# Altium schematic sheet PSU.SchDoc
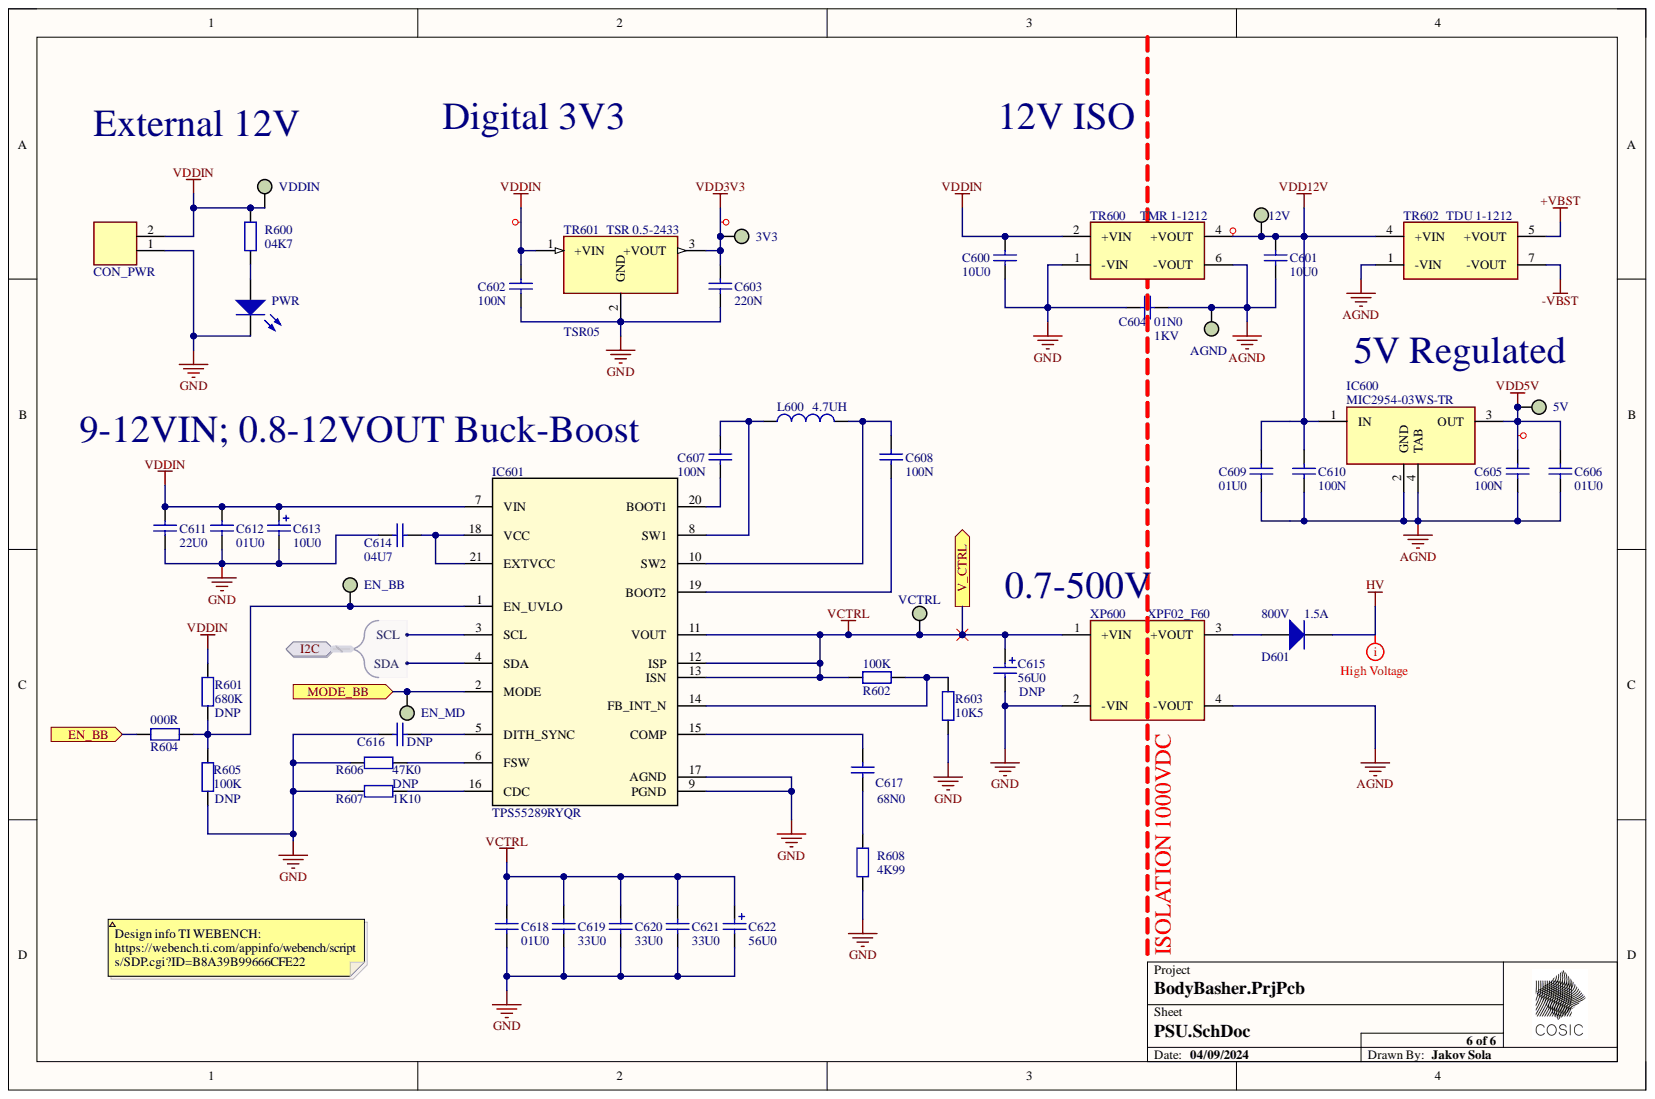
[diagram p0: PSU.SchDoc]

## Parts
3V3  TP  [TP]  (value not drawn)
5V  TP  [TP]  (value not drawn)
12V  TP  [TP]  (value not drawn)
AGND  TP  [TP]  (value not drawn)
C600  VALUE_4C: 10U0  [CAPC0603N]  (hidden comment: Cap)
C601  VALUE_4C: 10U0  [CAPC0603N]  (hidden comment: Cap)
C602  value 100N  [CAPC0603N]  (hidden comment: Cap)
C603  value 220N  [CAPC0603N]  (hidden comment: Cap)
C604  VALUE_4C: 01N0  VOLTAGE: 1KV  [CAPC1206N]  (hidden comment: Cap)
C605  value 100N  [CAPC0603N]  (hidden comment: Cap)
C606  VALUE_4C: 01U0  [CAPC0603N]  (hidden comment: Cap)
C607  value 100N  [CAPC0603N]  (hidden comment: Cap)
C608  value 100N  [CAPC0603N]  (hidden comment: Cap)
C609  VALUE_4C: 01U0  [CAPC0603N]  (hidden comment: Cap)
C610  value 100N  [CAPC0603N]  (hidden comment: Cap)
C611  value 22U0  [CAPC1206N]  (hidden comment: Cap)
C612  VALUE_4C: 01U0  [CAPC0603N]  (hidden comment: Cap)
C613  VALUE_4C: 10U0  [C]  (hidden comment: CapPol)
C614  value 04U7  [CAPC0603N]  (hidden comment: Cap)
C615  POPULATE: DNP  VALUE_4C: 56U0  [20SVPF56MX]  (hidden comment: CapPol)
C616  POPULATE: DNP  [CAPC0603N]  (hidden comment: Cap)
C617  VALUE_4C: 68N0  [CAPC0603N]  (hidden comment: Cap)
C618  VALUE_4C: 01U0  [CAPC0603N]  (hidden comment: Cap)
C619  VALUE_4C: 33U0  [CAPC1206N]  (hidden comment: Cap)
C620  VALUE_4C: 33U0  [CAPC1206N]  (hidden comment: Cap)
C621  VALUE_4C: 33U0  [CAPC1206N]  (hidden comment: Cap)
C622  VALUE_4C: 56U0  [20SVPF56MX]  (hidden comment: CapPol)
CON_PWR  CON_2P  [1711725]  (value not drawn)
D601  IF: 1.5A  VR: 800V  [DIOSMB]  (hidden comment: Diode)
EN_BB  TP  [TP]  (value not drawn)
EN_MD  TP  [TP]  (value not drawn)
IC600  MIC2954-03WS-TR  [SOT230P700X180-4N]
IC601  TPS55289RYQR  [RYQ0021A-MFG]
L600  INDUCTANCE: 4.7UH  [SRN80404R7Y]  (hidden comment: Inductor)
PWR  LED  [LED0603_GREEN]  (value not drawn)
R600  value 04K7  [RESC0603N]  (hidden comment: Res)
R601  value 680K  POPULATE: DNP  [RESC0603N]  (hidden comment: Res)
R602  value 100K  [RESC0603N]  (hidden comment: Res)
R603  value 10K5  [RESC0603N]  (hidden comment: Res)
R604  value 000R  [RESC0603N]  (hidden comment: Res)
R605  value 100K  POPULATE: DNP  [RESC0603N]  (hidden comment: Res)
R606  VALUE_4C: 47K0  [RESC0603N]  (hidden comment: Res)
R607  POPULATE: DNP  VALUE_4C: 1K10  [RESC0603N]  (hidden comment: Res)
R608  value 4K99  [RESC0603N]  (hidden comment: Res)
TR600  TMR1  [TMR1]
TR601  TSR05  [TSR05]
TR602  TDU1  [TDU1]
VCTRL  TP  [TP]  (value not drawn)
VDDIN  TP  [TP]  (value not drawn)
XP600  XPF02_F60  [XPF02_F60]

## Ports
EN_BB, I2C, I2C.SCL, I2C.SDA, MODE_BB, V_CTRL

## Nets
+VBST: TR602.5(+VOUT)
-VBST: TR602.7(-VOUT)
AGND: AGND.TP C601.1 C604.2 C605.1 C606.1 C609.1 C610.1 IC600.2(GND) IC600.4(TAB) TR600.6(-VOUT) TR602.1(-VIN) XP600.4(-VOUT)
GND: C600.1 C602.1 C603.1 C604.1 C611.1 C612.1 C613.2 C614.1 C615.2 C616.2 C618.1 C619.1 C620.1 C621.1 C622.2 CON_PWR.1 IC601.9(PGND) IC601.17(AGND) PWR.2 R603.2 R605.1 R606.2 R607.1 R608.1 TR600.1(-VIN) TR601.2 XP600.2(-VIN)
HV: D601.2
VDD3V3: 3V3.TP C603.2 TR601.3
VDD5V: 5V.TP C605.2 C606.2 IC600.3(OUT)
VDD12V: 12V.TP C601.2 C609.2 C610.2 IC600.1(IN) TR600.4(+VOUT) TR602.4(+VIN)
VDDIN: C600.2 C602.2 C611.2 C612.2 C613.1 CON_PWR.2 IC601.7(VIN) R600.2 R601.2 TR600.2(+VIN) TR601.1 VDDIN.TP
EN_BB: R604.1 ; port EN_BB
MODE_BB: EN_MD.TP IC601.2(MODE) ; port MODE_BB
VCTRL: C615.1 C618.2 C619.2 C620.2 C621.2 C622.1 IC601.11(VOUT) IC601.12(ISP) IC601.13(ISN) R602.1 VCTRL.TP XP600.1(+VIN) ; port V_CTRL
NetC607_1: C607.1 IC601.20(BOOT1)
NetC607_2: C607.2 IC601.8(SW1) L600.1
NetC608_1: C608.1 IC601.19(BOOT2)
NetC608_2: C608.2 IC601.10(SW2) L600.2
NetC614_2: C614.2 IC601.18(VCC) IC601.21(EXTVCC)
NetC616_1: C616.1 IC601.5(DITH_SYNC)
NetC617_1: C617.1 R608.2
NetC617_2: C617.2 IC601.15(COMP)
NetD601_1: D601.1 XP600.3(+VOUT)
NetEN_BB_TP: EN_BB.TP IC601.1(EN_UVLO) R601.1 R604.2 R605.2
NetIC601_3: IC601.3(SCL) ; port I2C
NetIC601_4: IC601.4(SDA) ; port I2C
NetIC601_6: IC601.6(FSW) R606.1
NetIC601_14: IC601.14(FB_INT_N) R602.2 R603.1
NetIC601_16: IC601.16(CDC) R607.2
NetPWR_1: PWR.1 R600.1

## Library data of the parts
3V3 5V 12V AGND EN_BB EN_MD VCTRL VDDIN = TP: Database Table Name: Misc$; DESCR: TESTPOINT 1.5MM; POPULATE: NA; Component Kind: Standard; Library Name: Other_passive.Dblib; MANUFCODE: TP
C600 C601 = ZRB18AR61E106ME01L: MANUF: MURATA; Database Table Name: Fixed_capacitors$; DESCR: CAP 10U0 0603 25V X5R 20%; POPULATE: YES; TOLERANCE: 0.2; VALUE: 10U; VALUE_PF: 10000000; VENDOR: FARNELL INONE; VENDORCODE: 2469398; VOLTAGE: 25V; Component Kind: Standard; Library Name: Capacitors.DbLib; MANUFCODE: ZRB18AR61E106ME01L; PACKAGE: 0603; TECHNOLOGY: X5R
C602 C605 C607 C608 C610 = CL10B104KA8WPNC: MANUF: SAMSUNG; Database Table Name: Fixed_capacitors$; DESCR: CAP 100N 0603 25V X7R 10%; POPULATE: YES; TOLERANCE: 0.1; VENDOR: DIGI-KEY; VENDORCODE: 1276-6717-1-ND; VOLTAGE: 25V; Component Kind: Standard; Library Name: Capacitors.DbLib; MANUFCODE: CL10B104KA8WPNC; PACKAGE: 0603; TECHNOLOGY: X7R; VALUE_4C: 100N
C603 = AC0603KRX7R6BB224: MANUF: YAGEO; Database Table Name: Fixed_capacitors$; DESCR: CAP 220N 0603 10V X7R 10%; POPULATE: YES; TOLERANCE: 0.1; VENDOR: DIGI-KEY; VENDORCODE: 311-3103-1-ND; VOLTAGE: 10V; Component Kind: Standard; Library Name: Capacitors.DbLib; MANUFCODE: AC0603KRX7R6BB224; PACKAGE: 0603; TECHNOLOGY: X7R; VALUE_4C: 220N
C604 = 1206AC102KAT2A: MANUF: AVX; Database Table Name: Fixed_capacitors$; DESCR: CAP 01N0 1206 1KV X7R 10%; POPULATE: YES; TOLERANCE: 0.1; VALUE_PF: 1000; VENDOR: FARNELL INONE; VENDORCODE: 1216463; Component Kind: Standard; Library Name: Capacitors.DbLib; MANUFCODE: 1206AC102KAT2A; PACKAGE: 1206; TECHNOLOGY: X7R
C606 C609 = C0603C105K4PAC-TU: MANUF: KEMET; Database Table Name: Fixed_capacitors$; DESCR: CAP 01U0 0603 16V X5R 10%; POPULATE: YES; TOLERANCE: 0.1; VALUE_PF: 1000000; VENDOR: FARNELL INONE; VENDORCODE: 1463388; VOLTAGE: 16V; Component Kind: Standard; Library Name: Capacitors.DbLib; MANUFCODE: C0603C105K4PAC-TU; PACKAGE: 0603; TECHNOLOGY: X5R
C611 = CL31A226KAHNNNE: MANUF: SAMSUNG; Database Table Name: Fixed_capacitors$; DESCR: CAP 22U0 1206 25V X5R 10%; POPULATE: YES; TOLERANCE: 0.1; VALUE_PF: 22000000; VENDOR: MOUSER; VENDORCODE: 187-CL31A226KAHNNNE; VOLTAGE: 25V; Component Kind: Standard; Library Name: Capacitors.DbLib; MANUFCODE: CL31A226KAHNNNE; PACKAGE: 1206; TECHNOLOGY: X5R; VALUE_4C: 22U0
C612 C618 = C0603C105K3PAC: MANUF: KEMET; Database Table Name: Fixed_capacitors$; DESCR: CAP 01U0 0603 25V X5R 10%; POPULATE: YES; TOLERANCE: 0.1; VALUE_PF: 1000000; VENDOR: FARNELL INONE; VENDORCODE: 1288202; VOLTAGE: 25V; Component Kind: Standard; Library Name: Capacitors.DbLib; MANUFCODE: C0603C105K3PAC; PACKAGE: 0603; TECHNOLOGY: X5R
C613 = TAJC106K025RNJ: MANUF: AVX; Database Table Name: Fixed_capacitors$; DESCR: CAP 10U0 C 25V TANT 10%; POPULATE: YES; TOLERANCE: 0.1; VALUE: 10U; VALUE_PF: 10000000; VENDOR: FARNELL INONE; VENDORCODE: 197518; VOLTAGE: 25V; Component Kind: Standard; Library Name: Capacitors.DbLib; MANUFCODE: TAJC106K025RNJ; PACKAGE: C; TECHNOLOGY: TANT
C614 = GRM185R61C475KE11D: MANUF: MURATA; Database Table Name: Fixed_capacitors$; DESCR: CAP 04U7 0603 16V X5R 10%; POPULATE: YES; TOLERANCE: 0.1; VALUE_PF: 4700000; VENDOR: DIGI-KEY; VENDORCODE: 490-14459-1-ND; VOLTAGE: 16V; Component Kind: Standard; Library Name: Capacitors.DbLib; MANUFCODE: GRM185R61C475KE11D; PACKAGE: 603; TECHNOLOGY: X5R; VALUE_4C: 04U7
C615 = 20SVPF56MX: MANUF: PANASONIC; Database Table Name: Fixed_capacitors$; DESCR: CAP 56U0 5X5.9 20V 20%; TOLERANCE: 0.2; VALUE: 56U; VALUE_PF: 56000000; VENDOR: MOUSER; VENDORCODE: 667-20SVPF56MX; VOLTAGE: 20V; Component Kind: Standard; Library Name: Capacitors.DbLib; MANUFCODE: 20SVPF56MX; PACKAGE: 5X5.9
C616 = C0603C105K3PAC: MANUF: KEMET; Database Table Name: Fixed_capacitors$; DESCR: CAP 01U0 0603 25V X5R 10%; TOLERANCE: 0.1; VALUE_4C: 01U0; VALUE_PF: 1000000; VENDOR: FARNELL INONE; VENDORCODE: 1288202; VOLTAGE: 25V; Component Kind: Standard; Library Name: Capacitors.DbLib; MANUFCODE: C0603C105K3PAC; PACKAGE: 0603; TECHNOLOGY: X5R
C617 = C0603C683K8RACTU: MANUF: KEMET; Database Table Name: Fixed_capacitors$; DESCR: CAP 68N0 0603 10V X7R 10%; POPULATE: YES; TOLERANCE: 0.1; VALUE: 68N; VENDOR: DIGI-KEY; VENDORCODE: 399-9122-1-ND; VOLTAGE: 10V; Component Kind: Standard; Library Name: Capacitors.DbLib; MANUFCODE: C0603C683K8RACTU; PACKAGE: 0603; TECHNOLOGY: X7R
C619-C621 = C3216X5R1E336M160AC: MANUF: TDK; Database Table Name: Fixed_capacitors$; DESCR: CAP 33U0 1206 25V X5R 20%; POPULATE: YES; TOLERANCE: 0.2; VALUE: 33U; VALUE_PF: 33000000; VENDOR: MOUSER; VENDORCODE: 810-C3216X5R1E336M; VOLTAGE: 25V; Component Kind: Standard; Library Name: Capacitors.DbLib; MANUFCODE: C3216X5R1E336M160AC; PACKAGE: 1206; TECHNOLOGY: X5R
C622 = 20SVPF56MX: MANUF: PANASONIC; Database Table Name: Fixed_capacitors$; DESCR: CAP 56U0 5X5.9 20V 20%; POPULATE: YES; TOLERANCE: 0.2; VALUE: 56U; VALUE_PF: 56000000; VENDOR: MOUSER; VENDORCODE: 667-20SVPF56MX; VOLTAGE: 20V; Component Kind: Standard; Library Name: Capacitors.DbLib; MANUFCODE: 20SVPF56MX; PACKAGE: 5X5.9
CON_PWR = 1711725: MANUF: PHOENIX CONTACT; Database Table Name: Misc$; DESCR: CON PHOENIX 5.08MM 2P SCREW; POPULATE: YES; VENDOR: MOUSER; VENDORCODE: 651-1711725; Component Kind: Standard; Library Name: Other_passive.Dblib; MANUFCODE: 1711725
D601 = RS2K-E3/52T: Default Diode; MANUF: VISHAY; Database Table Name: Power_diodes$; DESCR: DIODE 800V 1.5A SMB; POPULATE: YES; VENDOR: MOUSER; VENDORCODE: 625-RS2K-E3; Component Kind: Standard; Library Name: Diodes.Dblib; MANUFCODE: RS2K-E3/52T; PACKAGE: SMB; QTY: SINGLE
IC600 = MIC2954-03WS-TR: MANUF: MICROCHIP; Database Table Name: IC$; DESCR: IC LDO 2-30VIN 5VOUT 250mA; POPULATE: YES; VENDOR: MOUSER; VENDORCODE: 998-MIC2954-03WSTR; Component Kind: Standard; Library Name: Integrated_circuits.DbLib; MANUFCODE: MIC2954-03WS-TR
IC601 = TPS55289RYQR: MANUF: TI; Database Table Name: IC$; DESCR: IC BUCK-BOOST 30V 8A I2C; POPULATE: YES; VENDOR: MOUSER; VENDORCODE: 595-TPS55289RYQR; Component Kind: Standard; Library Name: Integrated_circuits.DbLib; MANUFCODE: TPS55289RYQR
L600 = SRN8040-4R7Y: MANUF: BOURNS; Database Table Name: Inductors$; DESCR: INDUCTOR 04U7 4A1 R024 SMD; IMAX: 4A1; POPULATE: YES; RESISTANCE: R024; VALUE: 04U7; VENDOR: MOUSER; VENDORCODE: 652-SRN8040-4R7Y; Component Kind: Standard; Library Name: Other_passive.Dblib; MANUFCODE: SRN8040-4R7Y; TYPE: INDUCTOR
PWR = ASMT-RF45: MANUF: AVAGO TECHNOLOGIES; Database Table Name: LEDs$; DESCR: LED GREEN 0603 20MA 2.4V 60MCD; IF: 20MA; INTENSITY: 60MCD; POPULATE: YES; REMARK: GREEN; VENDOR: FARNELL INONE; VENDORCODE: 1652078; VF: 2.4V; COLOR: GREEN; Component Kind: Standard; Library Name: Diodes.Dblib; MANUFCODE: ASMT-RF45; PACKAGE: 0603; QTY: SINGLE
R600 = CRCW06034K70JNEAC: Resistor; MANUF: VISHAY; Database Table Name: Fixed_resistors$; DESCR: RES 04K7 0603 0.1W 5% 75V 200PPM; NPOWER: 0.1; NTEMPCOEFF: 200; NTOLERANCE: 0.05; NVALUE: 4700000; NVOLTAGE: 75; POPULATE: YES; POWER: 0.1W; TEMPCOEFF: 200PPM; TOLERANCE: 5%; VENDOR: DIGI-KEY; VENDORCODE: 541-3993-1-ND; VOLTAGE: 75V; Component Kind: Standard; Library Name: Resistors.Dblib; MANUFCODE: CRCW06034K70JNEAC; PACKAGE: 0603; VALUE_4C: 04K7; VALUE_MOHM: 4700000
R601 = CRCW0603680KFKEA: Resistor; MANUF: VISHAY; Database Table Name: Fixed_resistors$; DESCR: RES 680K 0603 0.1W 1% 75V 100PPM; NPOWER: 0.1; NTEMPCOEFF: 100; NTOLERANCE: 0.01; NVALUE: 680000000; NVOLTAGE: 75; POWER: 0.1W; TEMPCOEFF: 100PPM; TOLERANCE: 1%; VENDOR: DIGI-KEY; VENDORCODE: 541-680KHCT-ND; VOLTAGE: 75V; Component Kind: Standard; Library Name: Resistors.Dblib; MANUFCODE: CRCW0603680KFKEA; PACKAGE: 0603; VALUE_4C: 680K; VALUE_MOHM: 680000000
R602 = MC 0.063W 0603 1% 100K: Resistor; MANUF: MULTICOMP; Database Table Name: Fixed_resistors$; DESCR: RES 100K 0603 0.063W 1% 50V 100PPM; NPOWER: 0.063; NTEMPCOEFF: 100; NTOLERANCE: 0.01; NVALUE: 100000000; NVOLTAGE: 50; POPULATE: YES; POWER: 0.063W; TEMPCOEFF: 100PPM; TOLERANCE: 1%; VENDOR: FARNELL INONE; VENDORCODE: 9330402; VOLTAGE: 50V; Component Kind: Standard; Library Name: Resistors.Dblib; MANUFCODE: MC 0.063W 0603 1% 100K; PACKAGE: 0603; PCB3D: RES0603; VALUE_4C: 100K; VALUE_MOHM: 100000000
R603 = MC0063W0603110K5: Resistor; MANUF: MULTICOMP; Database Table Name: Fixed_resistors$; DESCR: RES 10K5 0603 0.063W 1% 50V 100PPM; NPOWER: 0.063; NTEMPCOEFF: 100; NTOLERANCE: 0.01; NVALUE: 10500000; NVOLTAGE: 50; POPULATE: YES; POWER: 0.063W; TEMPCOEFF: 100PPM; TOLERANCE: 1%; VENDOR: FARNELL INONE; VENDORCODE: 2130940; VOLTAGE: 50V; Component Kind: Standard; Library Name: Resistors.Dblib; MANUFCODE: MC0063W0603110K5; PACKAGE: 0603; PCB3D: RES0603; VALUE_4C: 10K5; VALUE_MOHM: 10500000
R604 = CRCW06030000Z0EA: Resistor; MANUF: VISHAY; Database Table Name: Fixed_resistors$; DESCR: RES 000R 0603 0.1W 1% 75V 100PPM; NPOWER: 0.1; NTEMPCOEFF: 100; NTOLERANCE: 0.01; NVALUE: 0; NVOLTAGE: 75; POPULATE: YES; POWER: 0.1W; TEMPCOEFF: 100PPM; TOLERANCE: 1%; VENDOR: DIGI-KEY; VENDORCODE: 541-0.0GTR-ND; VOLTAGE: 75V; Component Kind: Standard; Library Name: Resistors.Dblib; MANUFCODE: CRCW06030000Z0EA; PACKAGE: 0603; VALUE_4C: 000R; VALUE_MOHM: 0
R605 = MC 0.063W 0603 1% 100K: Resistor; MANUF: MULTICOMP; Database Table Name: Fixed_resistors$; DESCR: RES 100K 0603 0.063W 1% 50V 100PPM; NPOWER: 0.063; NTEMPCOEFF: 100; NTOLERANCE: 0.01; NVALUE: 100000000; NVOLTAGE: 50; POWER: 0.063W; TEMPCOEFF: 100PPM; TOLERANCE: 1%; VENDOR: FARNELL INONE; VENDORCODE: 9330402; VOLTAGE: 50V; Component Kind: Standard; Library Name: Resistors.Dblib; MANUFCODE: MC 0.063W 0603 1% 100K; PACKAGE: 0603; PCB3D: RES0603; VALUE_4C: 100K; VALUE_MOHM: 100000000
R606 = CRCW060347K0FKEA: Resistor; MANUF: VISHAY; Database Table Name: Fixed_resistors$; DESCR: RES 47K0 0603 0.1W 1% 75V 100PPM; NPOWER: 0.1; NTEMPCOEFF: 100; NTOLERANCE: 0.01; NVALUE: 47000000; NVOLTAGE: 75; POPULATE: YES; POWER: 0.1W; TEMPCOEFF: 100PPM; TOLERANCE: 1%; VALUE: 47K; VENDOR: DIGI-KEY; VENDORCODE: 541-47.0KHCT-ND; VOLTAGE: 75V; Component Kind: Standard; Library Name: Resistors.Dblib; MANUFCODE: CRCW060347K0FKEA; PACKAGE: 0603; VALUE_MOHM: 47000000
R607 = CRCW06031K10FKEA: Resistor; MANUF: VISHAY; Database Table Name: Fixed_resistors$; DESCR: RES 1K10 0603 0.1W 1% 75V 100PPM; NPOWER: 0.1; NTEMPCOEFF: 100; NTOLERANCE: 0.01; NVALUE: 1100000; NVOLTAGE: 75; POWER: 0.1W; TEMPCOEFF: 100PPM; TOLERANCE: 1%; VALUE: 1K1; VENDOR: DIGI-KEY; VENDORCODE: 541-1.10KHCT-ND; VOLTAGE: 75V; Component Kind: Standard; Library Name: Resistors.Dblib; MANUFCODE: CRCW06031K10FKEA; PACKAGE: 0603; VALUE_MOHM: 1100000
R608 = MC 0.063W 0603 1% 4K99: Resistor; MANUF: MULTICOMP; Database Table Name: Fixed_resistors$; DESCR: RES 4K99 0603 0.1W 1% 50V 100PPM; NPOWER: 0.063; NTEMPCOEFF: 100; NTOLERANCE: 0.01; NVALUE: 4990000; NVOLTAGE: 50; POPULATE: YES; POWER: 0.063W; TEMPCOEFF: 100PPM; TOLERANCE: 1%; VENDOR: FARNELL INONE; VENDORCODE: 1170859; VOLTAGE: 50V; Component Kind: Standard; Library Name: Resistors.Dblib; MANUFCODE: MC 0.063W 0603 1% 4K99; PACKAGE: 0603; VALUE_4C: 4K99; VALUE_MOHM: 4990000
TR600 = TMR 1-1212: MANUF: TRACO; Database Table Name: IC$; DESCR: IC TR 9-18 VIN 12 VOUT REG ISO 1W; POPULATE: YES; VENDOR: MOUSER; VENDORCODE: 495-TMR1-1212; Component Kind: Standard; Library Name: Integrated_circuits.DbLib; MANUFCODE: TMR 1-1212
TR601 = TSR 0.5-2433: MANUF: TRACO; Database Table Name: IC$; DESCR: IC TR 4.75-32 VIN 3.3 VOUT 0.5A; POPULATE: YES; VENDOR: MOUSER; VENDORCODE: 495-TSR0.5-2433; Component Kind: Standard; Library Name: Integrated_circuits.DbLib; MANUFCODE: TSR 0.5-2433
TR602 = TDU 1-1212: MANUF: TRACO; Database Table Name: IC$; DESCR: IC TR 10.8-13.2 VIN 12 VOUT ISO 1W; POPULATE: YES; VENDOR: MOUSER; VENDORCODE: 495-TDU1-1212; Component Kind: Standard; Library Name: Integrated_circuits.DbLib; MANUFCODE: TDU 1-1212
XP600 = F05: MANUF: XP POWER; Database Table Name: IC$; DESCR: IC DCDC 0.8-12VIN 0-500 VOUT 10W; POPULATE: YES; VENDOR: MOUSER; VENDORCODE: 209-F05; Component Kind: Standard; Library Name: Integrated_circuits.DbLib; MANUFCODE: F05
